# Altium schematic sheet Dustbike_Core_Top.SchDoc(Dustbike_Core_Top)
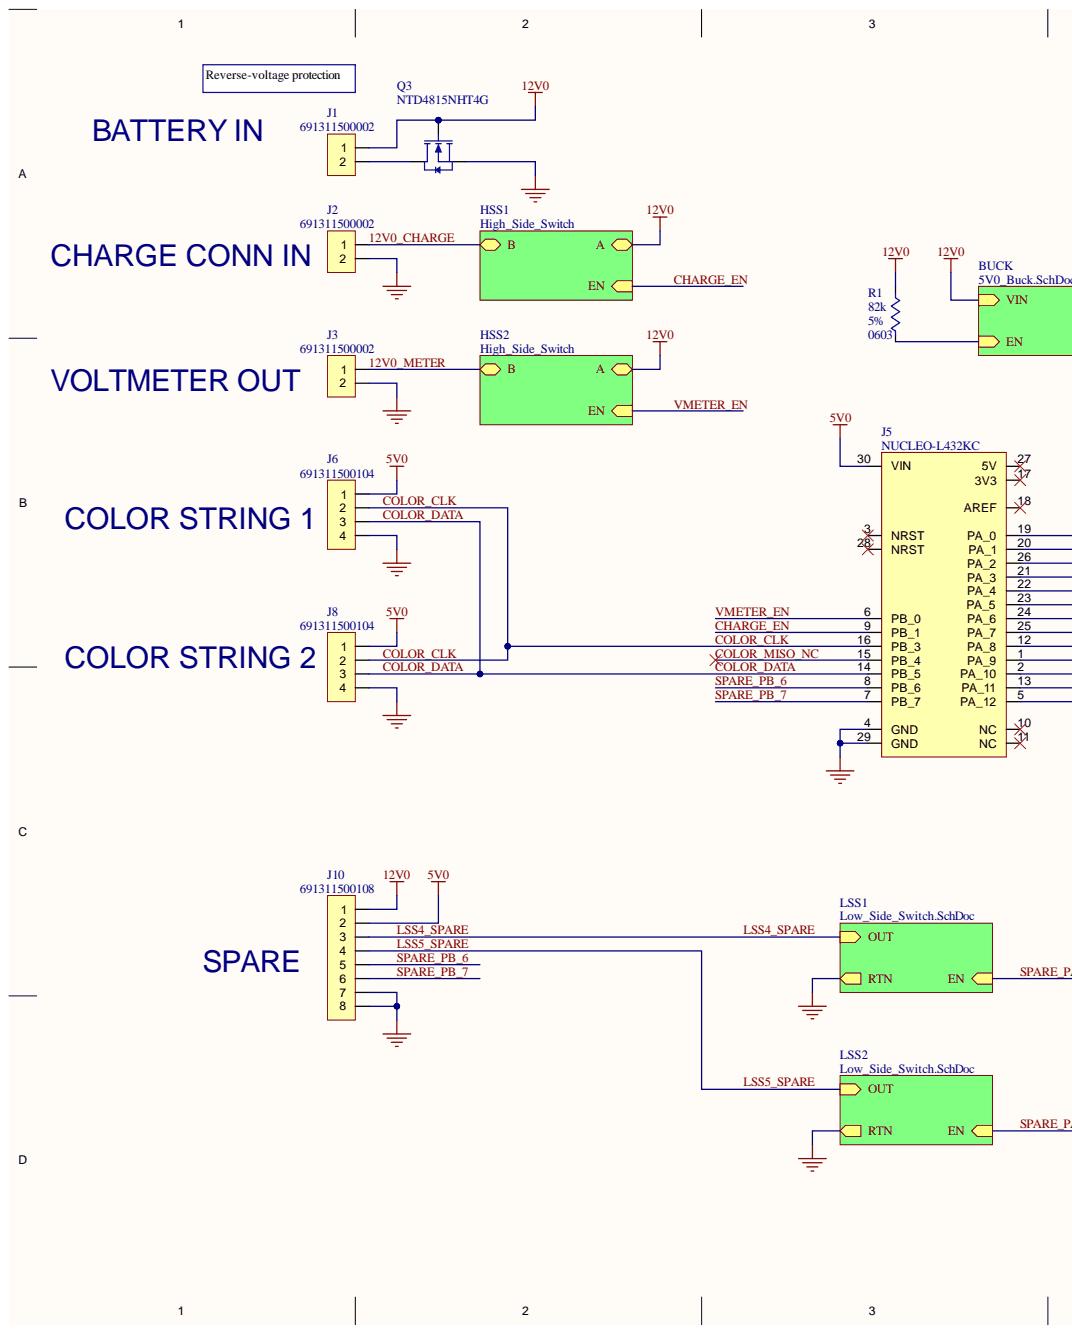
[diagram p0: Dustbike_Core_Top.SchDoc, left]
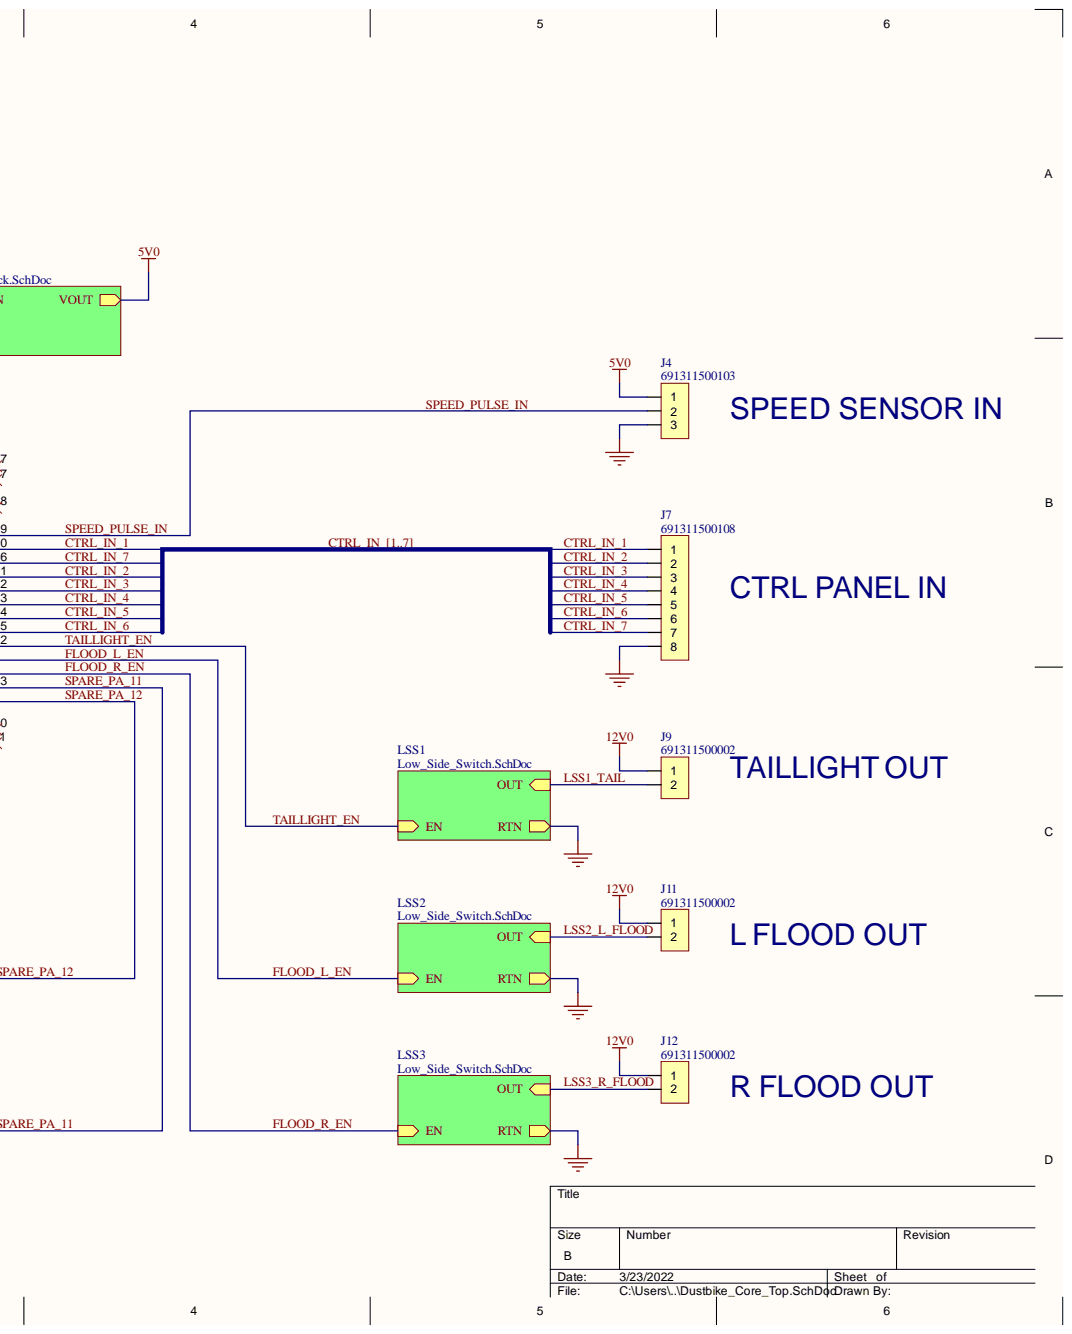
[diagram p1: Dustbike_Core_Top.SchDoc, right]

## Parts
J1  Manufacturer Part Number 1: 691311500002  [WURTH_691311500002]  (hidden comment: HDR_2POS)
J2  Manufacturer Part Number 1: 691311500002  [WURTH_691311500002]  (hidden comment: HDR_2POS)
J3  Manufacturer Part Number 1: 691311500002  [WURTH_691311500002]  (hidden comment: HDR_2POS)
J4  Manufacturer Part Number 1: 691311500103  [WURTH_691311500103]  (hidden comment: HDR_3POS)
J5  Manufacturer Part Number 1: NUCLEO-L432KC  [NANO_HEADER]  (hidden comment: NUCLEO_NANO)
J6  Manufacturer Part Number 1: 691311500104  [WURTH_691311500104]  (hidden comment: HDR_4POS)
J7  Manufacturer Part Number 1: 691311500108  [WURTH_691311500108]  (hidden comment: HDR_8POS)
J8  Manufacturer Part Number 1: 691311500104  [WURTH_691311500104]  (hidden comment: HDR_4POS)
J9  Manufacturer Part Number 1: 691311500002  [WURTH_691311500002]  (hidden comment: HDR_2POS)
J10  Manufacturer Part Number 1: 691311500108  [WURTH_691311500108]  (hidden comment: HDR_8POS)  (no BOM)
J11  Manufacturer Part Number 1: 691311500002  [WURTH_691311500002]  (hidden comment: HDR_2POS)
J12  Manufacturer Part Number 1: 691311500002  [WURTH_691311500002]  (hidden comment: HDR_2POS)
Q3  Manufacturer Part Number 1: NTD4815NHT4G  [DPAK228P991X238-3N_NTD4815NHT4G]  (hidden comment: NFET_SOT323)
R1  value 82k  Package: 0603  Tolerance: 5%  [RESC1508X09N]  (hidden comment: RES)

## Nets
5V0: J4.1 J5.30(VIN) J6.1 J8.1 J10.2
12V0: J1.1 J9.1 J10.1 J11.1 J12.1 Q3.G R1.2
GND: J2.2 J3.2 J4.3 J5.4(GND) J5.29(GND) J6.4 J7.8 J8.4 J10.7 J10.8 Q3.S
12V0_CHARGE: J2.1
12V0_METER: J3.1
CHARGE_EN: J5.9(PB_1)
COLOR_CLK: J5.16(PB_3) J6.2 J8.2
COLOR_DATA: J5.14(PB_5) J6.3 J8.3
COLOR_MISO_NC: J5.15(PB_4)
CTRL_IN_1: J5.20(PA_1) J7.1  [also labelled CTRL_IN_]
CTRL_IN_2: J5.21(PA_3) J7.2  [also labelled CTRL_IN_]
CTRL_IN_3: J5.22(PA_4) J7.3  [also labelled CTRL_IN_]
CTRL_IN_4: J5.23(PA_5) J7.4  [also labelled CTRL_IN_]
CTRL_IN_5: J5.24(PA_6) J7.5  [also labelled CTRL_IN_]
CTRL_IN_6: J5.25(PA_7) J7.6  [also labelled CTRL_IN_]
CTRL_IN_7: J5.26(PA_2) J7.7  [also labelled CTRL_IN_]
FLOOD_L_EN: J5.1(PA_9)
FLOOD_R_EN: J5.2(PA_10)
LSS1_TAIL: J9.2
LSS2_L_FLOOD: J11.2
LSS3_R_FLOOD: J12.2
LSS4_SPARE: J10.3
LSS5_SPARE: J10.4
SPARE_PA_11: J5.13(PA_11)
SPARE_PA_12: J5.5(PA_12)
SPARE_PB_6: J5.8(PB_6) J10.5
SPARE_PB_7: J5.7(PB_7) J10.6
SPEED_PULSE_IN: J4.2 J5.19(PA_0)
TAILLIGHT_EN: J5.12(PA_8)
VMETER_EN: J5.6(PB_0)
NetJ1_2: J1.2 Q3.D
NetJ5_3: J5.3(NRST)
NetJ5_10: J5.10(NC)
NetJ5_11: J5.11(NC)
NetJ5_17: J5.17(3V3)
NetJ5_18: J5.18(AREF)
NetJ5_27: J5.27(5V)
NetJ5_28: J5.28(NRST)
NetR1_1: R1.1

## Library data of the parts
J1-J3 J9 J11 J12 = GEN-CN-0001: TERM BLOCK HDR 2POS VERT 5.08MM; Manufacturer 1: Würth Elektronik; Body Color: Green; Connector Type: Header, Male Pins, Shrouded (2 Side); Current Rating: 20A; Database Table Name: general$; Gender: Male Pins; Number of Positions/Contacts: 2; Voltage - Rated: 300V; Component Kind: Standard; Contact Material: Brass; Contact Material - Plating: Brass; Housing Material: Polybutylene Terephthalate (PBT); Library Name: connectors.DbLib; Mounting Type: Through Hole; Operating Temperature: -40°C ~ 105°C; Series: WR-TBL Series 3211; Supplier 1: Digi-Key; Supplier Link 1: https://www.digikey.com/en/products/detail/w%C3%BCrth-elektronik/691311500002/2060548; Supplier Part Number 1: 732-2052-ND; TPN: GEN-CN-0001
J4 = GEN-CN-0002: TERM BLOCK HDR 3POS VERT 5.08MM; Manufacturer 1: Würth Elektronik; Body Color: Green; Connector Type: Header, Male Pins, Shrouded (4 Side); Current Rating: 20A; Database Table Name: general$; Gender: Male Pins; Number of Positions/Contacts: 3; Voltage - Rated: 300V; Component Kind: Standard; Contact Material: Brass; Contact Material - Plating: Brass; Housing Material: Polybutylene Terephthalate (PBT); Library Name: connectors.DbLib; Mounting Type: Through Hole; Operating Temperature: -40°C ~ 105°C; Series: WR-TBL Series 311; Supplier 1: Digi-Key; Supplier Link 1: https://www.digikey.com/en/products/detail/w%C3%BCrth-elektronik/691311500002/2060548; Supplier Part Number 1: 732-2059-ND; TPN: GEN-CN-0002
J5 = MOD-0001: NUCLEO-32 STM32L432KC EVAL BRD; Manufacturer 1: STMicroelectronics; Database Table Name: Modules$; Component Kind: Standard; Library Name: ics.DbLib; Supplier 1: Digi-Key; Supplier Link 1: https://www.digikey.com/en/products/detail/NUCLEO-L432KC/497-16592-ND/6132763?itemSeq=297939740; Supplier Part Number 1: 497-16592-ND; TPN: MOD-0001
J6 J8 = GEN-CN-0003: TERM BLOCK HDR 4POS VERT 5.08MM; Manufacturer 1: Würth Elektronik; Body Color: Green; Connector Type: Header, Male Pins, Shrouded (4 Side); Current Rating: 20A; Database Table Name: general$; Gender: Male Pins; Number of Positions/Contacts: 4; Voltage - Rated: 300V; Component Kind: Standard; Contact Material: Brass; Contact Material - Plating: Brass; Housing Material: Polybutylene Terephthalate (PBT); Library Name: connectors.DbLib; Mounting Type: Through Hole; Operating Temperature: -40°C ~ 105°C; Series: WR-TBL Series 311; Supplier 1: Digi-Key; Supplier Link 1: https://www.digikey.com/en/products/detail/w%C3%BCrth-elektronik/691311500104/2060556; Supplier Part Number 1: 732-2060-ND; TPN: GEN-CN-0003
J7 J10 = GEN-CN-0004: TERM BLOCK HDR 8POS VERT 5.08MM; Manufacturer 1: Würth Elektronik; Body Color: Green; Connector Type: Header, Male Pins, Shrouded (4 Side); Current Rating: 20A; Database Table Name: general$; Gender: Male Pins; Number of Positions/Contacts: 8; Voltage - Rated: 300V; Contact Material: Brass; Contact Material - Plating: Brass; Housing Material: Polybutylene Terephthalate (PBT); Library Name: connectors.DbLib; Mounting Type: Through Hole; Operating Temperature: -40°C ~ 105°C; Series: WR-TBL Series 311; Supplier 1: Digi-Key; Supplier Link 1: https://www.digikey.com/en/products/detail/w%C3%BCrth-elektronik/691311500108/2060559; Supplier Part Number 1: 732-2063-ND; TPN: GEN-CN-0004
  J7: Component Kind: Standard
Q3 = NMOS-0002: MOSFET N-CH 30V 6.9A/35A DPAK; Manufacturer 1: onsemi; Current - Max Continuous: 6.9A; Database Table Name: NMOS$; Drive Voltage (Max Rds On, Min Rds On): 4.5V, 11.5V; Gate Charge (Qg) (Max) @ Vgs: 6.8 nC @ 4.5 V; Rds On (Max) @ Id, Vgs: 15mOhm @ 30A, 10V; Type: NFET; Vgs (Max): 20V; Vgs(th) (Max) @ Id: 2.5V @ 250µA; Component Kind: Standard; ComponentLink1Description: Datasheet; ComponentLink1URL: https://www.onsemi.com/pdf/datasheet/ntd4815nh-d.pdf; ComponentLink2Description: Supplier Link; ComponentLink2URL: https://www.digikey.com/en/products/detail/onsemi/NTD4815NHT4G/1792971; Drain to Source Voltage (Vdss): 30V; Gate Charge (Qg) @ Vgs: 6.8 nC @ 4.5 V; Library Name: discretes.DbLib; Operating Temperature: -55°C ~ 175°C (TJ); Package / Case: TO-252-3, DPak (2 Leads + Tab), SC-63; Supplier 1: Digi-Key; Supplier Part Number 1: NTD4815NHT4GOSCT-ND; TPN: NMOS-0002
R1 = RES-SM-0288: RES 82K OHM 5% 0603; Database Table Name: Surface Mount$; Power Rating: 0.125; Pulse-Rated: No; Component Kind: Standard; Library Name: resistors.DbLib; TPN: RES-SM-0288
